# Altium schematic sheet 3.WIZ750SR_EVB_CON.SchDoc
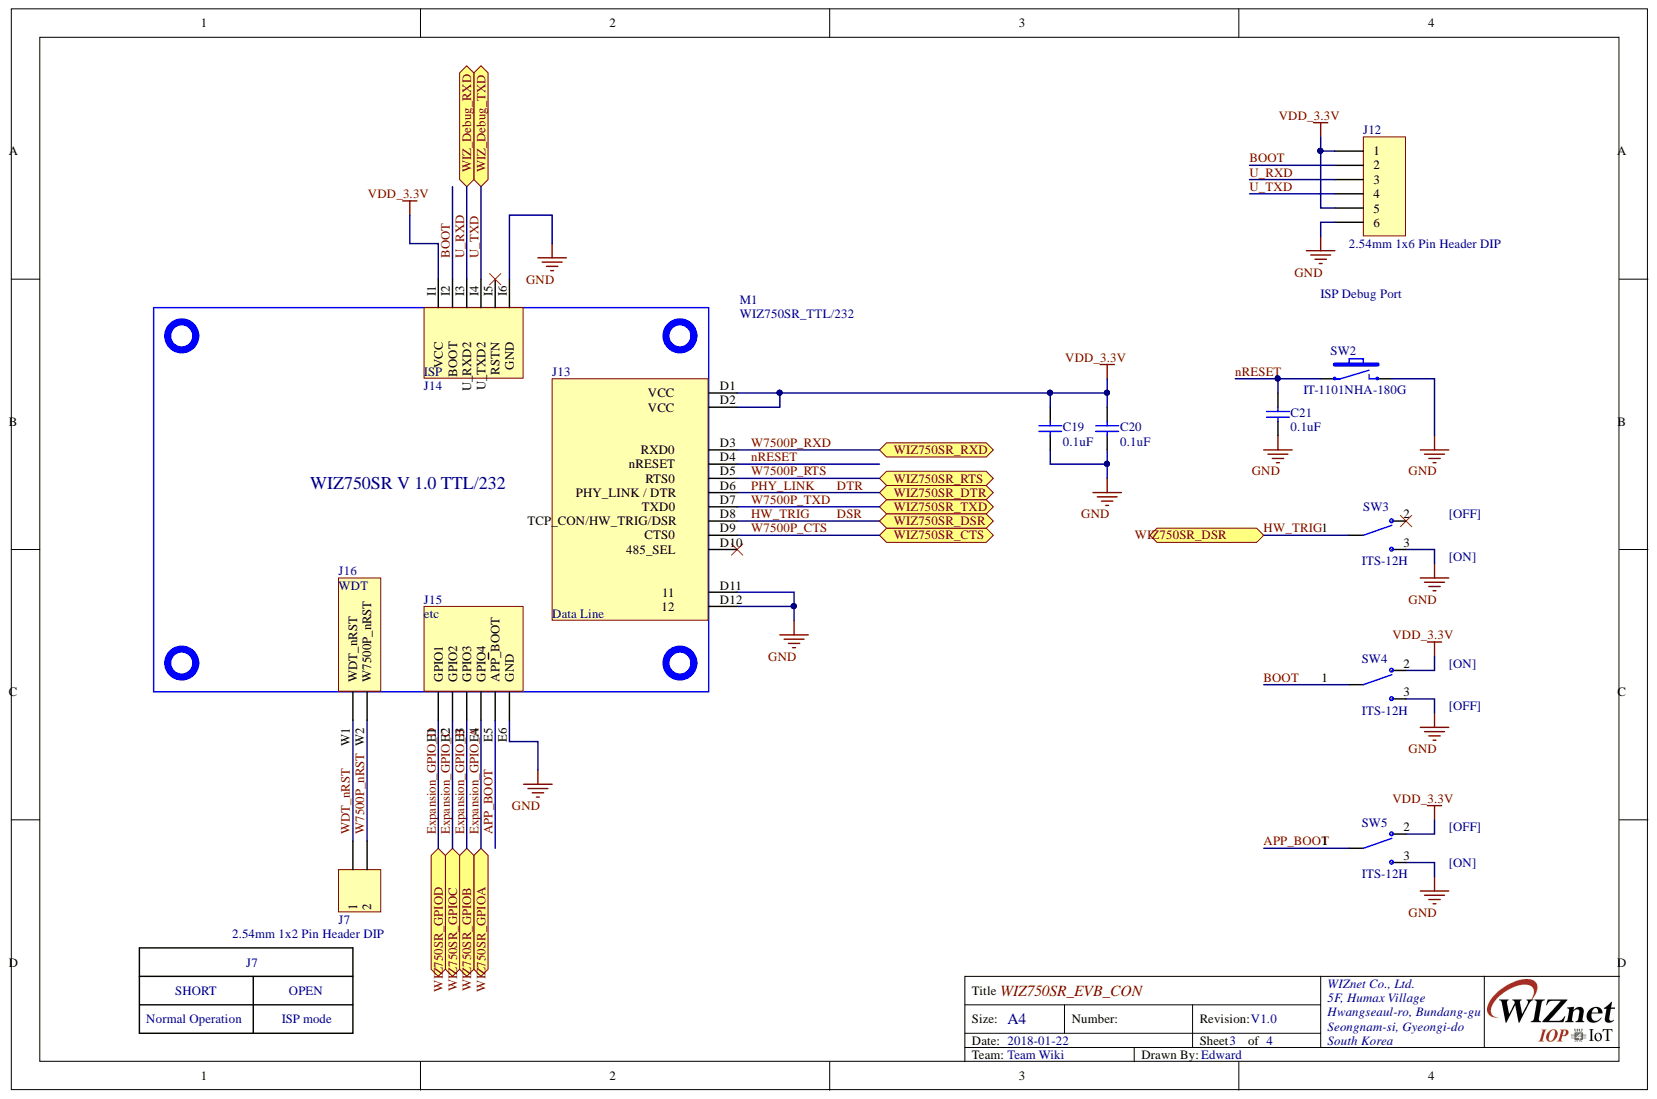
[diagram p0: 3.WIZ750SR_EVB_CON.SchDoc]

## Parts
C19  0.1uF  [CAP-0603]
C20  0.1uF  [CAP-0603]
C21  0.1uF  [CAP-0603]
J7  2.54mm 1x2 Pin Header DIP  [IDC-1X2]
J12  2.54mm 1x6 Pin Header DIP  [IDC-1X6]
M1  WIZ750SR_TTL/232  [WIZ750SR_V1.0_TTL/232]
SW2  IT-1101NHA-180G  [IT-1101NHA]
SW3  ITS-12H  [ITS-12H]
SW4  ITS-12H  [ITS-12H]
SW5  ITS-12H  [ITS-12H]

## Ports
WIZ750SR_CTS, WIZ750SR_DSR, WIZ750SR_DTR, WIZ750SR_GPIOA, WIZ750SR_GPIOB, WIZ750SR_GPIOC, WIZ750SR_GPIOD, WIZ750SR_RTS, WIZ750SR_RXD, WIZ750SR_TXD, WIZ_DEBUG_RXD, WIZ_DEBUG_TXD

## Nets
GND: C19.1 C20.1 C21.1 J12.6 M1.D11 M1.D12 M1.E6(GND) M1.I6 SW2.2 SW3.3 SW4.3 SW5.3
VDD_3.3V: C19.2 C20.2 J12.1 J12.5 M1.D1 M1.D2 M1.I1 SW4.2 SW5.2
APP_BOOT: M1.E5(APP_BOOT) SW5.1
BOOT: J12.2 M1.I2 SW4.1
DSR: M1.D8 SW3.1 ; port WIZ750SR_DSR
DTR: M1.D6 ; port WIZ750SR_DTR
EXPANSION_GPIO_A: M1.E4(GPIO4) ; port WIZ750SR_GPIOA
EXPANSION_GPIO_B: M1.E3(GPIO3) ; port WIZ750SR_GPIOB
EXPANSION_GPIO_C: M1.E2(GPIO2) ; port WIZ750SR_GPIOC
EXPANSION_GPIO_D: M1.E1(GPIO1) ; port WIZ750SR_GPIOD
NRESET: C21.2 M1.D4 SW2.1
U_RXD: J12.3 M1.I3 ; port WIZ_DEBUG_RXD
U_TXD: J12.4 M1.I4 ; port WIZ_DEBUG_TXD
W7500P_CTS: M1.D9 ; port WIZ750SR_CTS
W7500P_NRST: J7.2 M1.W2(W7500P_nRST)
W7500P_RTS: M1.D5 ; port WIZ750SR_RTS
W7500P_RXD: M1.D3 ; port WIZ750SR_RXD
W7500P_TXD: M1.D7 ; port WIZ750SR_TXD
WDT_NRST: J7.1 M1.W1(WDT_nRST)

Not connected: M1 D10 I5; SW3 2

## Library data of the parts
C19-C21 = CAP-0603: Ceramic CAP 0603(1608); Component Kind: Standard; Library Name: Scott_lib.IntLib
J7 = Header 2: Header, 2-Pin; Component Kind: Standard; LatestRevisionDate: 17-Jul-2002; LatestRevisionNote: Re-released for DXP Platform.; Signal Integrity: Connector; Library Name: Edward.IntLib; Publisher: Altium Limited
J12 = Header 6: Header, 6-Pin; Component Kind: Standard; LatestRevisionDate: 17-Jul-2002; LatestRevisionNote: Re-released for DXP Platform.; Signal Integrity: Connector; Library Name: Edward.IntLib; Publisher: Altium Limited
M1 = WIZ750SR_V1.0_TTL/232: Serial to ethernet module, 45mmx30mm, TTL/232; Component Kind: Standard; Library Name: Edward.IntLib
SW2 = IT-1101NHA-180G: TACT Switch (SMD); Component Kind: Standard; Library Name: Scott_lib.IntLib
SW3-SW5 = ITS-12H: Slide Switch; Component Kind: Standard; Library Name: Scott_lib.IntLib
